# Altium schematic sheet 6-User IO.SchDoc
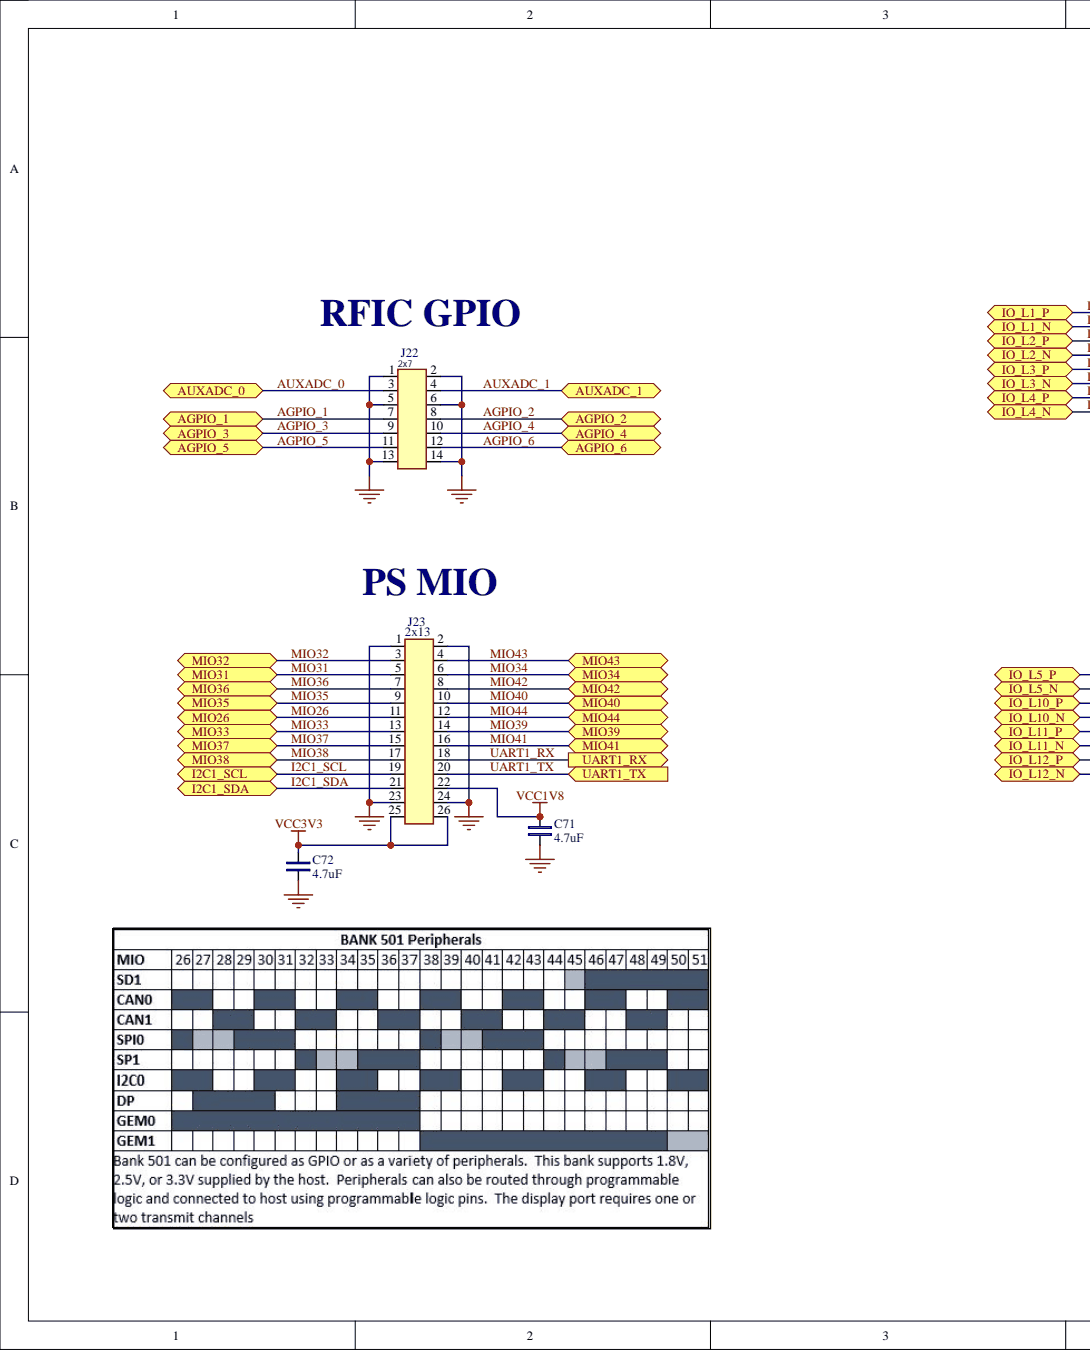
[diagram p0: 6-User IO.SchDoc, left]
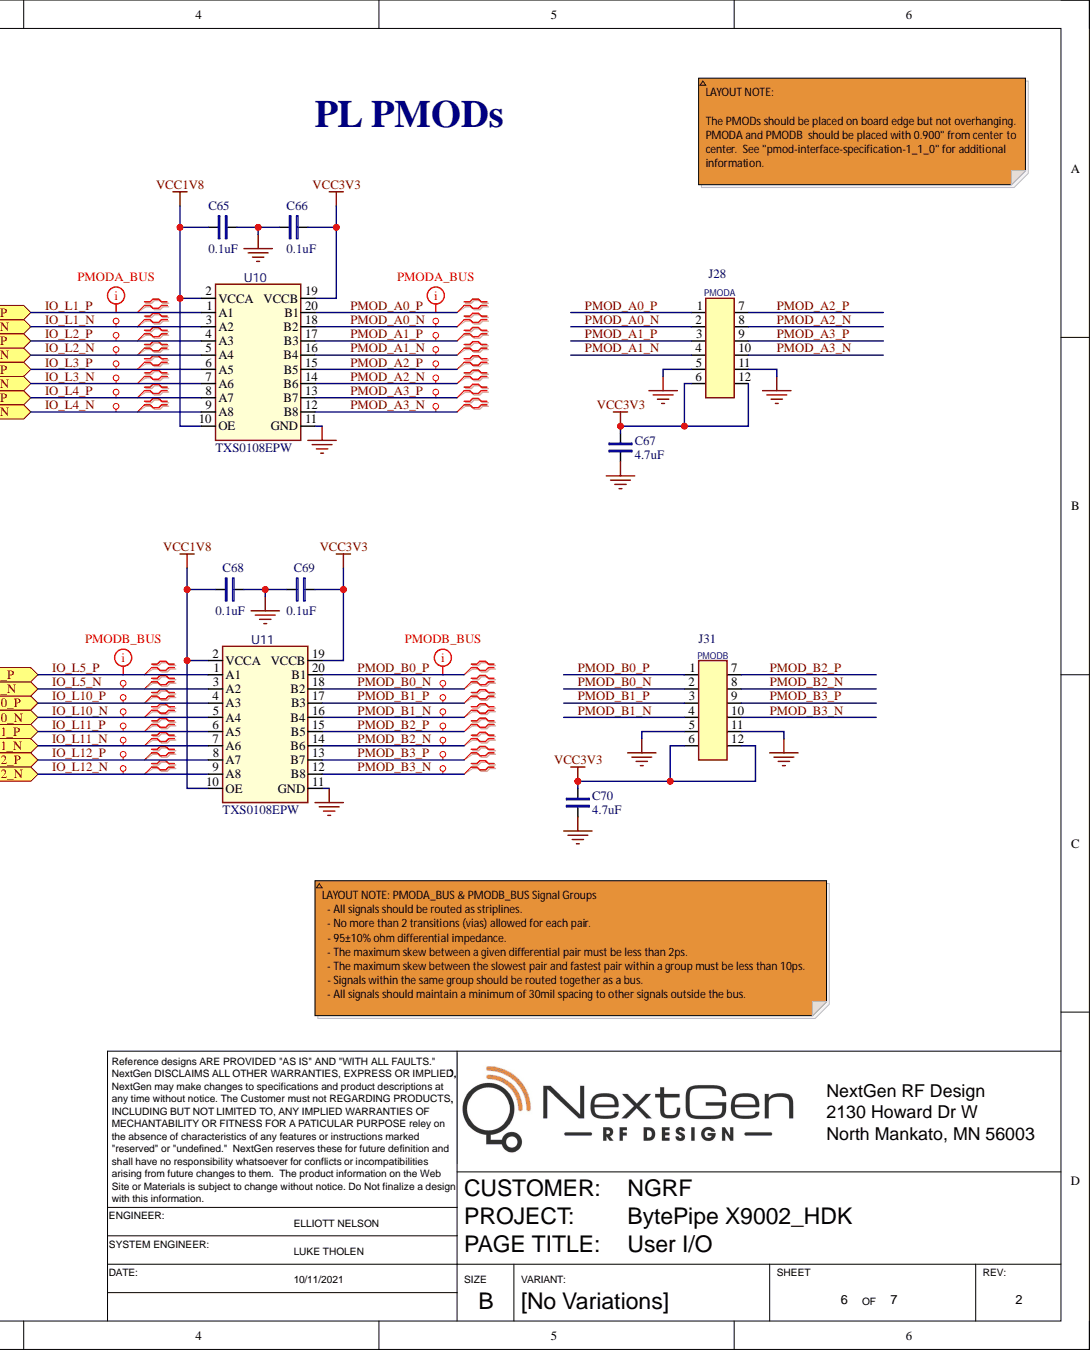
[diagram p1: 6-User IO.SchDoc, right]

## Parts
C65  value 0.1uF  [SM/0402/CAP]  (hidden comment: CAP_CERAMIC_0402)
C66  value 0.1uF  [SM/0402/CAP]  (hidden comment: CAP_CERAMIC_0402)
C67  value 4.7uF  [SM/0402/CAP]  (hidden comment: CAP_CERAMIC_0402)
C68  value 0.1uF  [SM/0402/CAP]  (hidden comment: CAP_CERAMIC_0402)
C69  value 0.1uF  [SM/0402/CAP]  (hidden comment: CAP_CERAMIC_0402)
C70  value 4.7uF  [SM/0402/CAP]  (hidden comment: CAP_CERAMIC_0402)
C71  value 4.7uF  [SM/0402/CAP]  (hidden comment: CAP_CERAMIC_0402)
C72  value 4.7uF  [SM/0402/CAP]  (hidden comment: CAP_CERAMIC_0402)
J22  value 2x7  [CON/100SP/2X7P/VT/038_A]  (hidden comment: CON_100SP_2X7P_VT)
J23  value 2x13  [CON/100SP/2X13P/038_A]  (hidden comment: CON_100SP_2X13P_VERT)
J28  value PMODA  [CON/SAMTEC/SSW/2X6/RA_A/PMOD]  (hidden comment: CON_100SP_2X6P_RA_PMOD)
J31  value PMODB  [CON/SAMTEC/SSW/2X6/RA_A/PMOD]  (hidden comment: CON_100SP_2X6P_RA_PMOD)
U10  value TXS0108EPW  OEM#: TXS0108EPW  [SM/TSSOP20_6.5X6.4MM]  (hidden comment: IC_TXS0108_TSSOP-20)
U11  value TXS0108EPW  OEM#: TXS0108EPW  [SM/TSSOP20_6.5X6.4MM]  (hidden comment: IC_TXS0108_TSSOP-20)

## Ports
AGPIO_1, AGPIO_2, AGPIO_3, AGPIO_4, AGPIO_5, AGPIO_6, AUXADC_0, AUXADC_1, I2C1_SCL, I2C1_SDA, IO_L1_N, IO_L1_P, IO_L2_N, IO_L2_P, IO_L3_N, IO_L3_P, IO_L4_N, IO_L4_P, IO_L5_N, IO_L5_P, IO_L10_N, IO_L10_P, IO_L11_N, IO_L11_P, IO_L12_N, IO_L12_P, MIO26, MIO31, MIO32, MIO33, MIO34, MIO35, MIO36, MIO37, MIO38, MIO39, MIO40, MIO41, MIO42, MIO43, MIO44, UART1_RX, UART1_TX

## Nets
GND: C65.2 C66.1 C67.2 C68.2 C69.1 C70.2 C71.2 C72.2 J22.1 J22.2 J22.5 J22.6 J22.13 J22.14 J23.1 J23.2 J23.23 J23.24 J28.5 J28.11 J31.5 J31.11 U10.11(GND) U11.11(GND)
VCC1V8: C65.1 C68.1 C71.1 J23.22 U10.2(VCCA) U10.10(OE) U11.2(VCCA) U11.10(OE)
VCC3V3: C66.2 C67.1 C69.2 C70.1 C72.1 J23.25 J23.26 J28.6 J28.12 J31.6 J31.12 U10.19(VCCB) U11.19(VCCB)
AGPIO_1: J22.7 ; port AGPIO_1
AGPIO_2: J22.8 ; port AGPIO_2
AGPIO_3: J22.9 ; port AGPIO_3
AGPIO_4: J22.10 ; port AGPIO_4
AGPIO_5: J22.11 ; port AGPIO_5
AGPIO_6: J22.12 ; port AGPIO_6
AUXADC_0: J22.3 ; port AUXADC_0
AUXADC_1: J22.4 ; port AUXADC_1
I2C1_SCL: J23.19 ; port I2C1_SCL
I2C1_SDA: J23.21 ; port I2C1_SDA
IO_L1_N: U10.3(A2) ; port IO_L1_N
IO_L1_P: U10.1(A1) ; port IO_L1_P
IO_L2_N: U10.5(A4) ; port IO_L2_N
IO_L2_P: U10.4(A3) ; port IO_L2_P
IO_L3_N: U10.7(A6) ; port IO_L3_N
IO_L3_P: U10.6(A5) ; port IO_L3_P
IO_L4_N: U10.9(A8) ; port IO_L4_N
IO_L4_P: U10.8(A7) ; port IO_L4_P
IO_L5_N: U11.3(A2) ; port IO_L5_N
IO_L5_P: U11.1(A1) ; port IO_L5_P
IO_L10_N: U11.5(A4) ; port IO_L10_N
IO_L10_P: U11.4(A3) ; port IO_L10_P
IO_L11_N: U11.7(A6) ; port IO_L11_N
IO_L11_P: U11.6(A5) ; port IO_L11_P
IO_L12_N: U11.9(A8) ; port IO_L12_N
IO_L12_P: U11.8(A7) ; port IO_L12_P
MIO26: J23.11 ; port MIO26
MIO31: J23.5 ; port MIO31
MIO32: J23.3 ; port MIO32
MIO33: J23.13 ; port MIO33
MIO34: J23.6 ; port MIO34
MIO35: J23.9 ; port MIO35
MIO36: J23.7 ; port MIO36
MIO37: J23.15 ; port MIO37
MIO38: J23.17 ; port MIO38
MIO39: J23.14 ; port MIO39
MIO40: J23.10 ; port MIO40
MIO41: J23.16 ; port MIO41
MIO42: J23.8 ; port MIO42
MIO43: J23.4 ; port MIO43
MIO44: J23.12 ; port MIO44
PMOD_A0_N: J28.2 U10.18(B2)
PMOD_A0_P: J28.1 U10.20(B1)
PMOD_A1_N: J28.4 U10.16(B4)
PMOD_A1_P: J28.3 U10.17(B3)
PMOD_A2_N: J28.8 U10.14(B6)
PMOD_A2_P: J28.7 U10.15(B5)
PMOD_A3_N: J28.10 U10.12(B8)
PMOD_A3_P: J28.9 U10.13(B7)
PMOD_B0_N: J31.2 U11.18(B2)
PMOD_B0_P: J31.1 U11.20(B1)
PMOD_B1_N: J31.4 U11.16(B4)
PMOD_B1_P: J31.3 U11.17(B3)
PMOD_B2_N: J31.8 U11.14(B6)
PMOD_B2_P: J31.7 U11.15(B5)
PMOD_B3_N: J31.10 U11.12(B8)
PMOD_B3_P: J31.9 U11.13(B7)
UART1_RX: J23.18 ; port UART1_RX
UART1_TX: J23.20 ; port UART1_TX

## Library data of the parts
C65 C66 C68 C69 = CAP_CERAMIC_0402: CAP CER 0.1UF 25V X7R 0402; OEM NAME: Samsung; OEM#: CL05B104KA5NNNC; TOL: ±10%; Component Kind: Standard; VOLT: 25V; Library Name: NextGenRF_Symbols_SMT.SchLib
C67 C70-C72 = CAP_CERAMIC_0402: CAP CER 4.7UF 10V X5R 0402; OEM NAME: Samsung; OEM#: CL05A475MP5NRNC; TOL: ±20%; Component Kind: Standard; VOLT: 10V; Library Name: NextGenRF_Symbols_SMT.SchLib
J22 = CON_100SP_2X7P_VT: Connector Header Through Hole 14 position 0.100" (2.54mm); OEM NAME: Harwin Inc.; OEM#: 2011-2X07TSB; Component Kind: Standard; Library Name: NextGenRF_Symbols_TH.SchLib
J23 = CON_100SP_2X13P_VERT: PIN HEADER, DOUBLE ROW 26 PIN, S; OEM NAME: Harwin Inc.; OEM#: M20-9981345; Component Kind: Standard; Library Name: NextGenRF_Symbols_TH.SchLib
J28 J31 = CON_100SP_2X6P_RA_PMOD: Header, 100 mil, 2x6, Right Angle, PMOD pin out.; OEM NAME: SAMTEC; OEM#: SSW-106-02-T-D-RA; Component Kind: Standard; Library Name: NextGenRF_Symbols_TH.SchLib
U10 U11 = (no symbol name): IC TRNSLTR BIDIRECTIONAL 20VQFN; OEM NAME: Texas Instruments; Component Kind: Standard; Library Name: NextGenRF_Symbols_SMT.SchLib
